# Altium schematic sheet sch.SchDoc
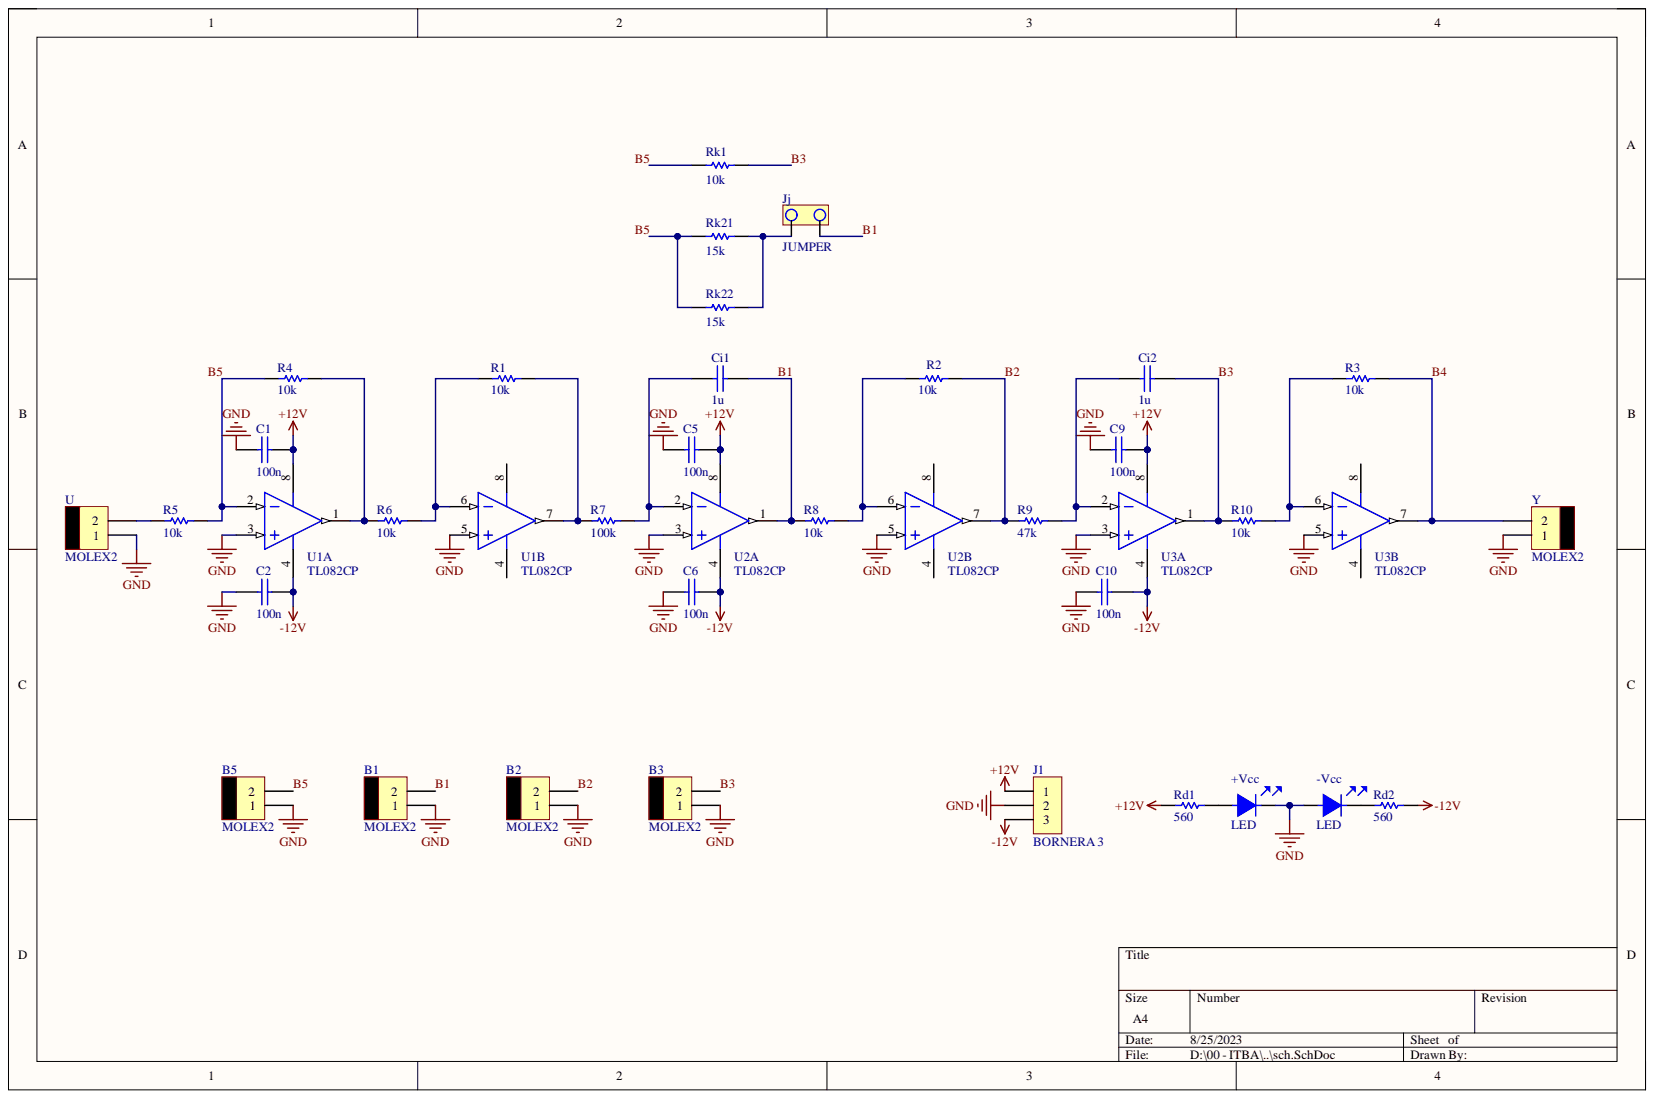
[diagram p0: sch.SchDoc]

## Parts
+Vcc  LED  [LED3]
-Vcc  LED  [LED3]
B1  MOLEX2  [MOLEX2]
B2  MOLEX2  [MOLEX2]
B3  MOLEX2  [MOLEX2]
B5  MOLEX2  [MOLEX2]
C1  100n  [CAP200]
C2  100n  [CAP100]
C5  100n  [CAP200]
C6  100n  [CAP100]
C9  100n  [CAP200]
C10  100n  [CAP100]
Ci1  1u  [CAP100]
Ci2  1u  [CAP100]
J1  BORNERA 3  [BORNERA3]
Jj  JUMPER  [JUMPER2]
R1  10k  [RES300]
R2  10k  [RES300]
R3  10k  [RES300]
R4  10k  [RES300]
R5  10k  [RES300]
R6  10k  [RES300]
R7  100k  [RES300]
R8  10k  [RES300]
R9  47k  [RES300]
R10  10k  [RES300]
Rd1  560  [RES300]
Rd2  560  [RES300]
Rk1  10k  [RES300]
Rk21  15k  [RES300]
Rk22  15k  [RES400]
U  MOLEX2  [MOLEX2]
U1  TL082CP  [P0008A]  (parts A,B)
U2  TL082CP  [P0008A]  (parts A,B)
U3  TL082CP  [P0008A]  (parts A,B)
Y  MOLEX2  [MOLEX2]

## Nets
+12V: C1.1 C5.1 C9.1 J1.1 Rd1.1 U1.8 U2.8 U3.8
-12V: C2.1 C6.1 C10.1 J1.3 Rd2.2 U1.4 U2.4 U3.4
GND: +Vcc.2 -Vcc.1 B1.1 B2.1 B3.1 B5.1 C1.2 C2.2 C5.2 C6.2 C9.2 C10.2 J1.2 U.1 U1.3 U1.5 U2.3 U2.5 U3.3 U3.5 Y.1
B1: B1.2 Ci1.1 Jj.1 R8.1 U2.1
B2: B2.2 R2.2 R9.1 U2.7
B3: B3.2 Ci2.1 R10.1 Rk1.2 U3.1
B4: R3.2 U3.7 Y.2
B5: B5.2 R4.1 R5.2 Rk1.1 Rk21.1 Rk22.1 U1.2
Net+Vcc_1: +Vcc.1 Rd1.2
Net-Vcc_2: -Vcc.2 Rd2.1
NetCi1_2: Ci1.2 R7.2 U2.2
NetCi2_2: Ci2.2 R9.2 U3.2
NetJj_2: Jj.2 Rk21.2 Rk22.2
NetR1_1: R1.1 R6.2 U1.6
NetR1_2: R1.2 R7.1 U1.7
NetR2_1: R2.1 R8.2 U2.6
NetR3_1: R3.1 R10.2 U3.6
NetR4_2: R4.2 R6.1 U1.1
NetR5_1: R5.1 U.2

## Library data of the parts
C1 C2 C5 C6 C9 C10 Ci1 Ci2 = CAP: Component Kind: Standard; Library Name: LIBEBAL.IntLib
R1-R10 Rd1 Rd2 Rk1 Rk21 Rk22 = RES: Component Kind: Standard; Library Name: LIBEBAL.IntLib
U1-U3 = CMP-0055-00158-5: JFET-Input Operational Amplifier, 7 to 36 V, 0 to 70 degC, 8-pin DIP (P8), Pb-Free (RoHS); Manufacturer: Texas Instruments; CMRR(Min)(dB): 70; GBW(Typ)(MHz): 3; IIB(Max)(pA): 400; Iq per channel(Max)(mA): 2.8; Number of Channels: 2; Offset Drift(Typ)(uV/C): 18; Rating: Catalog; Slew Rate(Typ)(V/us): 13; Sub Family: General Purpose Amplifier, High Supply Voltage Amplifier (>=30V); Total Supply Voltage(Max)(+5V is 5, +/-5V is 10): 36; Total Supply Voltage(Min)(+5V is 5, +/-5V is 10): 7; Vio (25C)(Max)(mV): 15; Code_JEDEC: MS-001; Component Kind: Standard; ComponentLink1Description: Manufacturer URL; ComponentLink1URL: http://www.ti.com/; ComponentLink2Description: Datasheet; ComponentLink2URL: http://www.ti.com/lit/ds/symlink/tl082.pdf; ComponentLink3Description: Package Specification; ComponentLink3URL: http://www.ti.com/litv/pdf/mpdi001b; DatasheetVersion: SLOS081G; Eco-Plan: Pb-Free (RoHS); Library Name: Altium Content Vault; Mounting Technology: Through Hole; PackageDescription: 8-Pin Plastic In-Line, Body 9.59 x 6.35 mm, Pitch 2.54 mm; PackageReference: P0008A; PackageVersion: 4040082/E, 04/2010; PartNumber: TL082CP; Vn at 1kHz(Typ)(nV/rtHz): 18
+Vcc -Vcc = LED: Component Kind: Standard; Library Name: LIBEBAL.IntLib
B1-B3 B5 U Y = MOLEX2: Component Kind: Standard; Library Name: LIBEBAL.IntLib
J1 = BORNERA 3: Component Kind: Standard; Library Name: LIBEBAL.IntLib
Jj = JUMPER: Component Kind: Standard; Library Name: LIBEBAL.IntLib
